# Altium schematic sheet ZB1.SchDoc(ZB1)
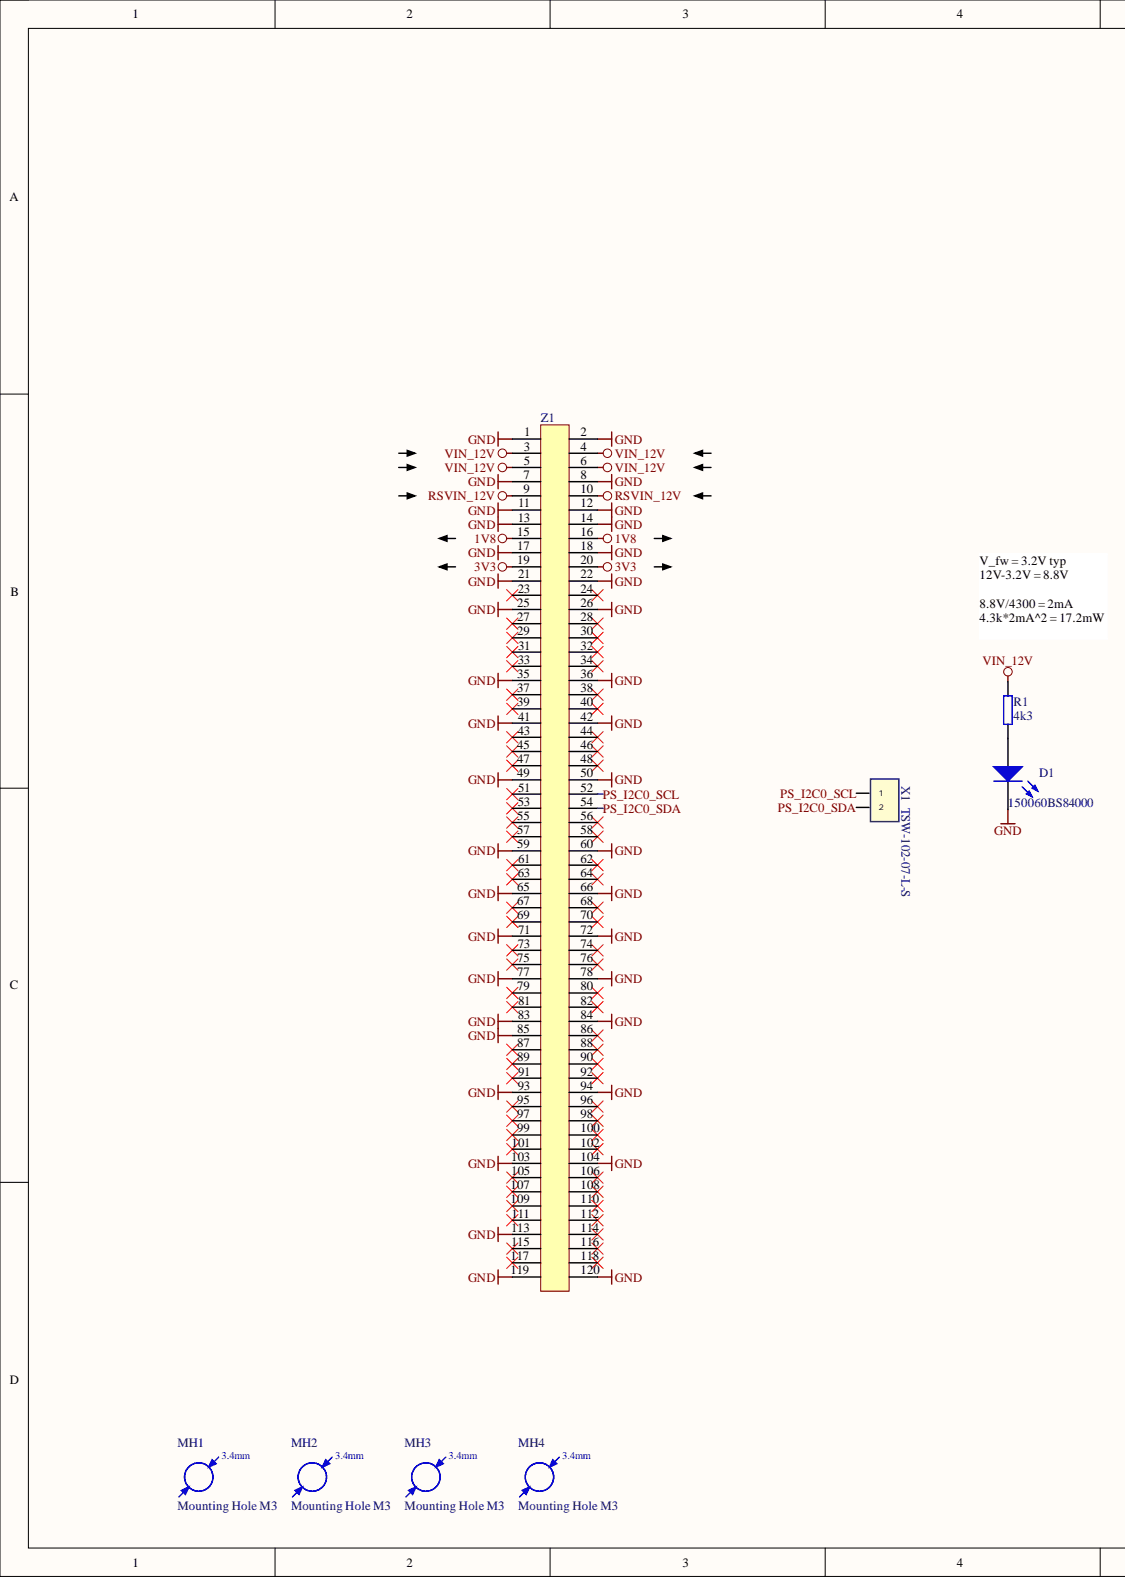
[diagram p0: ZB1.SchDoc, left]
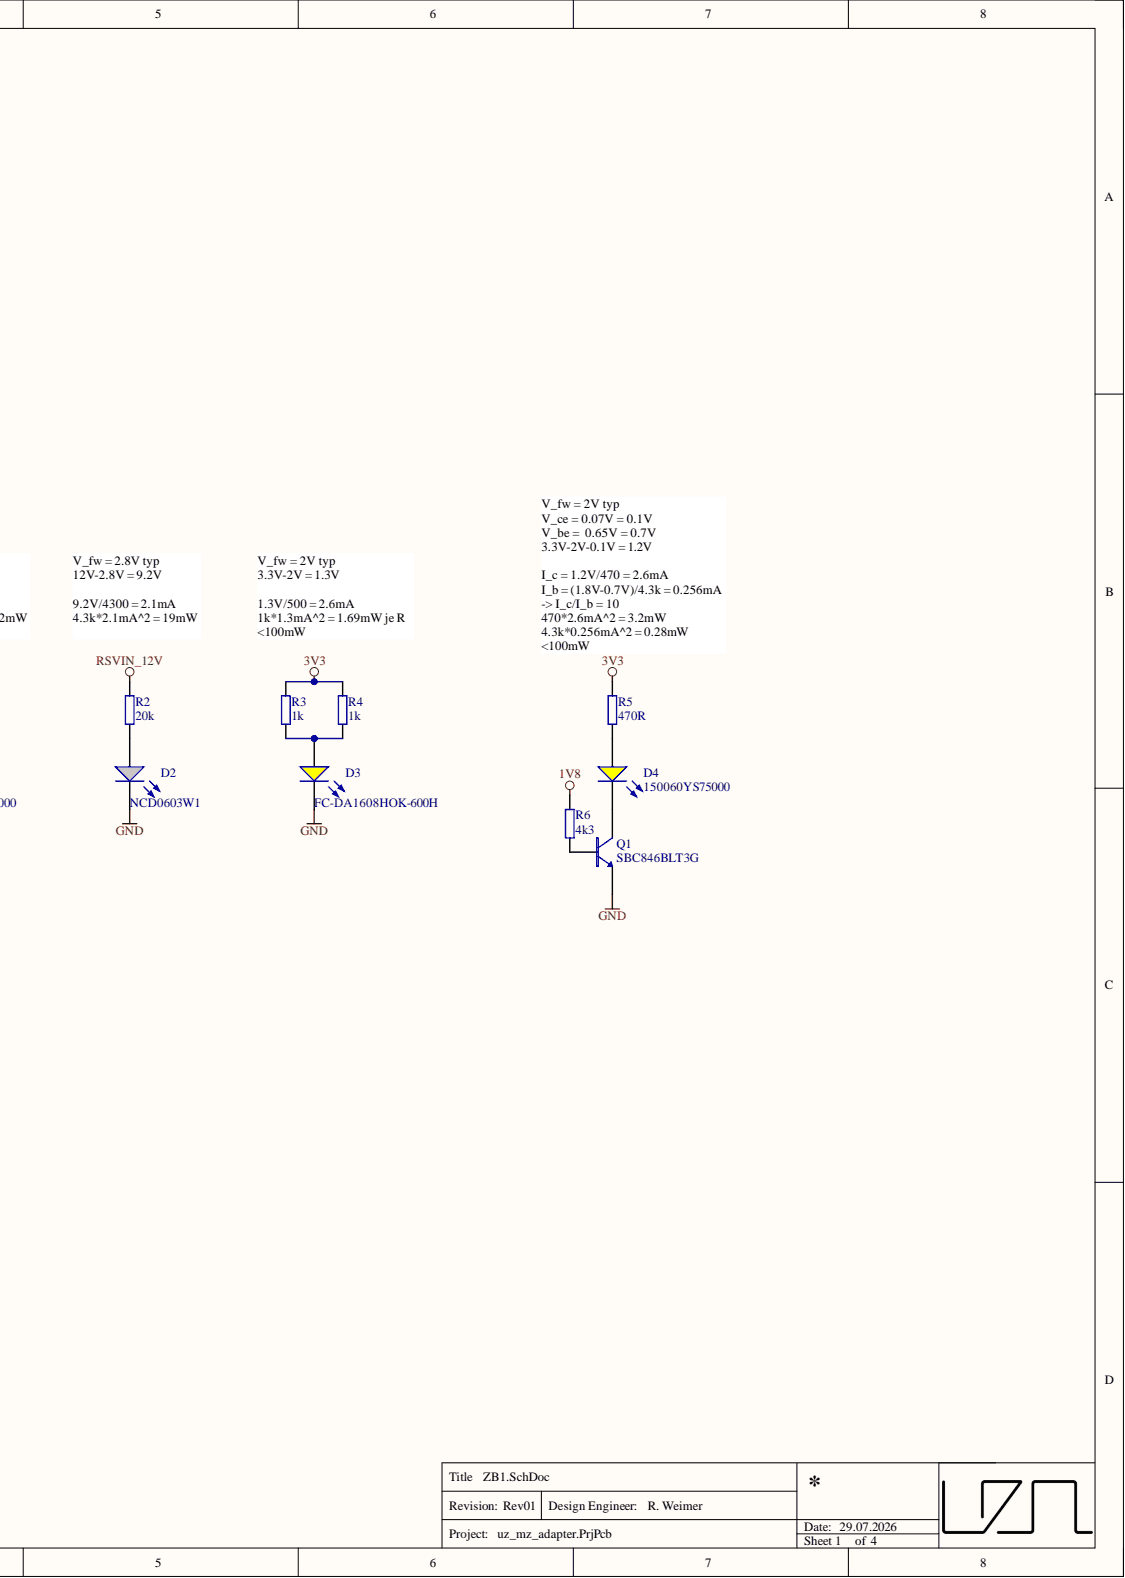
[diagram p1: ZB1.SchDoc, right]

## Parts
D1  150060BS84000  [WL-SMCW_0603]
D2  NCD0603W1  [WL-SMCW_0603]
D3  FC-DA1608HOK-600H  [WL-SMCW_0603]
D4  150060YS75000  [WL-SMCW_0603]
MH1  Mounting Hole M3  value Mounting Hole  [MH_3.4]  (no BOM)
MH2  Mounting Hole M3  value Mounting Hole  [MH_3.4]  (no BOM)
MH3  Mounting Hole M3  value Mounting Hole  [MH_3.4]  (no BOM)
MH4  Mounting Hole M3  value Mounting Hole  [MH_3.4]  (no BOM)
Q1  SBC846BLT3G  [SOT-23-3_ONSC]
R1  4k3  [RES 0603_1608]
R2  20k  [RES 0603_1608]
R3  1k  [RES 0603_1608]
R4  1k  [RES 0603_1608]
R5  470R  [RES 0603_1608]
R6  4k3  [RES 0603_1608]
X1  TSW-102-07-L-S  [TSW-102-XX-XXX-S]
Z1  ERM8-060-02.0-L-DV-TR  [ERM8-060-02.0-L-DV]  (value not drawn)

## Nets
1V8: R6.2 Z1.15 Z1.16
3V3: R3.2 R4.2 R5.2 Z1.19 Z1.20
GND: D1.1 D2.1 D3.1 Q1.2 Z1.1 Z1.2 Z1.7 Z1.8 Z1.11 Z1.12 Z1.13 Z1.14 Z1.17 Z1.18 Z1.21 Z1.22 Z1.25 Z1.26 Z1.35 Z1.36 Z1.41 Z1.42 Z1.49 Z1.50 Z1.59 Z1.60 Z1.65 Z1.66 Z1.71 Z1.72 Z1.77 Z1.78 Z1.83 Z1.84 Z1.85 Z1.93 Z1.94 Z1.103 Z1.104 Z1.113 Z1.119 Z1.120
RSVIN_12V: R2.2 Z1.9 Z1.10
VIN_12V: R1.2 Z1.3 Z1.4 Z1.5 Z1.6
PS_I2C0_SCL: X1.1 Z1.52
PS_I2C0_SDA: X1.2 Z1.54
NetD1_2: D1.2 R1.1
NetD2_2: D2.2 R2.1
NetD3_2: D3.2 R3.1 R4.1
NetD4_1: D4.1 Q1.3
NetD4_2: D4.2 R5.1
NetQ1_1: Q1.1 R6.1

Not connected: Z1 23 24 27 28 29 30 31 32 33 34 37 38 39 40 43 44 45 46 47 48 51 53 55 56 57 58 61 62 63 64 67 68 69 70 73 74 75 76 79 80 81 82 86 87 88 89 90 91 92 95 96 97 98 99 100 101 102 105 106 107 108 109 110 111 112 114 115 116 117 118

## Library data of the parts
D1 = 150060BS84000: WL-SMCW SMD Mono-color Chip LED Waterclear Blue; Manufacturer: Wuerth Elektronik; Created from: MGremer; Database Table Name: Diodes - LED; Forward Current I_f: 20 mA; Forward Voltage U_f: 3.2 V; Light Intensity: 560 mcd; Nominal Power: 78 mW; Type: Standard; Wavelength: 470 nm; Color: blue; Component Kind: Standard; Component Type: LED Diode; ComponentLink1Description: Datasheet; ComponentLink1URL: https://www.we-online.com/components/products/datasheet/150060BS75000.pdf; Created On: 08.12.2020 10:19:38; Device Package: 0603; Last Updated: 22.04.2026 11:55:05; LCSC Part Number: C5197644; Library Name: user.DbLib; Live Cycle Status: 1; Manufacturer Part Number: 150060BS84000; Mounting Type: SMD; Operating Temperature: -40 - 85 °C; PartID: 1; Stock number: not stored; Supplier 1: Mouser; Supplier 2: Farnell; Supplier 3: Digi-Key; Supplier Part Number 1: 710-150060BS84000; Supplier Part Number 2: 3408611; Supplier Part Number 3: 732-150060BS84000CT-ND
D2 = NCD0603W1: White light 0603 Light Emitting Diodes (LED) ROHS; Manufacturer: Foshan NationStar Optoelectronics; Created from: NDiringer; Database Table Name: Diodes - LED; Forward Current I_f: 20 mA; Forward Voltage U_f: 2.8 V; Light Intensity: 250 mcd; Nominal Power: 72 mW; Type: Standard; Color: white; Component Kind: Standard; Component Type: LED Diode; ComponentLink1Description: Datasheet; ComponentLink1URL: https://www.lcsc.com/datasheet/C84265.pdf; Created On: 03.05.2022 13:11:03; Device Package: 0603; Last Updated: 22.04.2026 11:55:05; LCSC Part Number: C84265; Library Name: user.DbLib; Live Cycle Status: 1; Manufacturer Part Number: NCD0603W1; Mounting Type: SMD; Operating Temperature: -40 - 85 °C; PartID: 13; Stock number: not stored; Supplier 1: LCSC; Supplier Part Number 1: C84265
D3 = FC-DA1608HOK-600H: LED ORANGE 600~610NM 80~220MCD@20MA TOP VIEW 0603 ROHS; Manufacturer: Foshan NationStar Optoelectronics; Color: amber; Created from: T. Wendt; Database Table Name: Diodes - LED; Forward Current I_f: 20 mA; Forward Voltage U_f: 2 V; Light Intensity: 150 mcd; Nominal Power: 40 mW; Type: Standard; Wavelength: 604 nm; Component Kind: Standard; Component Type: LED Diode; ComponentLink1Description: Datasheet; ComponentLink1URL: https://www.lcsc.com/datasheet/C84269.pdf; Created On: 03.03.2021 09:59:51; Device Package: 0603; Last Updated: 22.04.2026 11:55:05; LCSC Part Number: C84269; Library Name: user.DbLib; Live Cycle Status: 1; Manufacturer Part Number: FC-DA1608HOK-600H; Mounting Type: SMD; Operating Temperature: -40 - 85 °C; PartID: 10; Stock number: not stored; Supplier 1: LCSC; Supplier Part Number 1: C84269
D4 = 150060YS75000: LED Yellow 20mA 2V 0603; Manufacturer: Wuerth Elektronik; Created from: RWeimer; Database Table Name: Diodes - LED; Type: Standard; Wavelength: 590 nm; Color: yellow; Component Kind: Standard; Component Type: LED Diode; ComponentLink1Description: Datasheet; ComponentLink1URL: https://www.we-online.com/components/products/datasheet/150060YS75000.pdf; Created On: 03.06.2026 10:18:01; Device Package: 0603; Forward Current I_f: 20 mA; Forward Voltage U_f: 2 V; Last Updated: 03.06.2026 10:18:43; LCSC Part Number: C6784997; Library Name: user.DbLib; Live Cycle Status: 1; Manufacturer Part Number: 150060YS75000; Mounting Type: SMD; Operating Temperature: -40 to +85 °C; PartID: 37; Stock number: not stored; Supplier 1: LCSC; Supplier Part Number 1: C6784997; Value: LED Yellow
MH1-MH4 = Mounting Hole: Created from: MHlatky; Database Table Name: Misc; Component Type: Mounting Hole; ComponentLink1Description: Datasheet; Created On: 26.01.2021 09:19:51; Description: Mounting Hole; Device Package: -; Last Updated: 21.03.2022 23:05:12; Library Name: user.DbLib; Live Cycle Status: 1; Manufacturer: Mounting Hole; Manufacturer Part Number: Mounting Hole M3; PartID: 16; Stock number: not stored; Supplier 1: Mounting Hole; Supplier Part Number 1: Mounting Hole; Type: Mounting Hole
Q1 = SBC846BLT3G: Bipolartransistor, NPN 100mA 80V; Manufacturer: onsemi; Collector Emitter Voltage: 65 V; Created from: RWeimer; Database Table Name: Bipolartransistors; Number Of Channels: single; Power Dissipation: 225 mW; Value: 65 V; Collector Basis Voltage: 80 V; Component Kind: Standard; Component Type: Bipolartransistor; ComponentLink1Description: Datasheet; ComponentLink1URL: https://www.lcsc.com/datasheet/C896883.pdf; Created On: 22.06.2026 10:09:39; Device Package: SOT-23-3; Last Updated: 22.06.2026 10:09:39; LCSC Part Number: C896883; Library Name: user.DbLib; Live Cycle Status: 1; Manufacturer Part Number: SBC846BLT3G; Mounting Type: SMD; Operating Temperature: -55 - +150°C; PartID: 7; Polarization: NPN; Series: BC846BL; Supplier 1: Mouser; Supplier 2: LCSC; Supplier Part Number 1: 863-SBC846BLT3G; Supplier Part Number 2: C896883; Type: Bipolartransistor
R1 R6 = RK73B1JTTD432J: SMD resistor E24 series; Manufacturer: KOA Speer; Created from: MGremer; Database Table Name: Resistors - SMD; Tolerance: 5%; Component Kind: Standard; Component Type: SMD resistor; ComponentLink1Description: Datasheet; ComponentLink1URL: https://www.mouser.de/datasheet/2/219/RK73B-1825463.pdf; Created On: 14.12.2020 16:17:14; Device Package: 0603; Last Updated: 10.04.2026 07:56:16; LCSC Part Number: C307285; Library Name: user.DbLib; Live Cycle Status: 1; Manufacturer Part Number: RK73B1JTTD432J; Mounting Type: SMD; PartID: 89; Stock number: Labor SMD Container; Supplier 1: Mouser; Supplier Part Number 1: 660-RK73B1JTTD432J; Type: Resistor; Value: 4k3
R2 = 0603WAF2002T5E: SMD resistor E24 series; Manufacturer: UNI-ROYAL; Created from: AGeiger; Database Table Name: Resistors - SMD; Tolerance: 1%; Component Kind: Standard; Component Type: SMD resistor; ComponentLink1Description: Datasheet; ComponentLink1URL: https://datasheet.lcsc.com/lcsc/2206010230_UNI-ROYAL-Uniroyal-Elec-0603WAF2002T5E_C4184.pdf; Created On: 14.12.2020 16:17:14; Device Package: 0603; Last Updated: 25.08.2022 20:05:50; LCSC Part Number: C4184; Library Name: user.DbLib; Live Cycle Status: 1; Manufacturer Part Number: 0603WAF2002T5E; Mounting Type: SMD; PartID: 105; Stock number: Labor SMD Container; Supplier 1: LCSC; Supplier Part Number 1: C4184; Type: Resistor; Value: 20k
R3 R4 = 0603WAF1001T5E: SMD resistor E24 series; Manufacturer: UNI-ROYAL; Created from: AGeiger; Database Table Name: Resistors - SMD; Tolerance: 1%; Component Kind: Standard; Component Type: SMD resistor; ComponentLink1Description: Datasheet; ComponentLink1URL: https://datasheet.lcsc.com/lcsc/2206010130_UNI-ROYAL-Uniroyal-Elec-0603WAF1001T5E_C21190.pdf; Created On: 14.12.2020 16:17:14; Device Package: 0603; Last Updated: 25.08.2022 16:01:46; LCSC Part Number: C21190; Library Name: user.DbLib; Live Cycle Status: 1; Manufacturer Part Number: 0603WAF1001T5E; Mounting Type: SMD; PartID: 74; Stock number: Labor SMD Container; Supplier 1: LCSC; Supplier Part Number 1: C21190; Type: Resistor; Value: 1k
R5 = RK73B1JTTD471J: SMD resistor E24 series; Manufacturer: KOA Speer; Created from: MGremer; Database Table Name: Resistors - SMD; Tolerance: 5%; Component Kind: Standard; Component Type: SMD resistor; ComponentLink1Description: Datasheet; ComponentLink1URL: https://www.mouser.de/datasheet/2/219/RK73B-1825463.pdf; Created On: 14.12.2020 16:17:14; Device Package: 0603; Last Updated: 04.03.2021 10:03:02; LCSC Part Number: C23179; Library Name: user.DbLib; Live Cycle Status: 1; Manufacturer Part Number: RK73B1JTTD471J; Mounting Type: SMD; PartID: 66; Stock number: Labor SMD Container; Supplier 1: Mouser; Supplier 2: LCSC; Supplier Part Number 1: 660-RK73B1JTTD471J; Supplier Part Number 2: C23179; Type: Resistor; Value: 470R
X1 = TSW-102-07-L-S: Classic PCB Header Strips, 0.100" pitch; MANUFACTURER: SAMTEC; Created from: AGeiger; Database Table Name: Connector; Component Kind: Standard; ComponentLink1Description: Datasheet; ComponentLink1URL: https://suddendocs.samtec.com/catalog_english/tsw_th.pdf; Created On: 26.06.2023 09:51:05; Last Updated: 26.06.2023 09:53:59; LCSC Part Number: C492401; Library Name: user.DbLib; Manufacturer Part Number: TSW-102-07-L-S; Mounting Type: SMD; Operating Temperature: -55 °C to +105 °C; PartID: 20; PCB DECAL: TSW-102-XX-XXX-S; Series: TSW; Supplier 1: LCSC; Supplier Part Number 1: C492401; Value: TSW-102-07-L-S; CREATED BY: May 18, 2016 - Wendy Xin
Z1 = ERM8-060-02.0-L-DV-TR: 0.80 mm Edge Rate Rugged High Speed; Manufacturer: SAMTEC; Created from: AGeiger; Database Table Name: Connector; Component Kind: Standard; ComponentLink1Description: Datasheet; ComponentLink1URL: https://suddendocs.samtec.com/catalog_english/erm8.pdf; Created On: 15.08.2025 09:35:44; Last Updated: 23.09.2025 10:14:21; LCSC Part Number: C3649339; Library Name: user.DbLib; Manufacturer Part Number: ERM8-060-02.0-L-DV-TR; Mounting Type: SMD; Operating Temperature: -55 °C to +125 °C; PartID: 61; Series: ERM8; Supplier 1: LCSC; Supplier Part Number 1: C3649339; Value: ERM8-060-02.0-L-DV-TR
